# Altium schematic sheet MOLEX_connectors.SchDoc
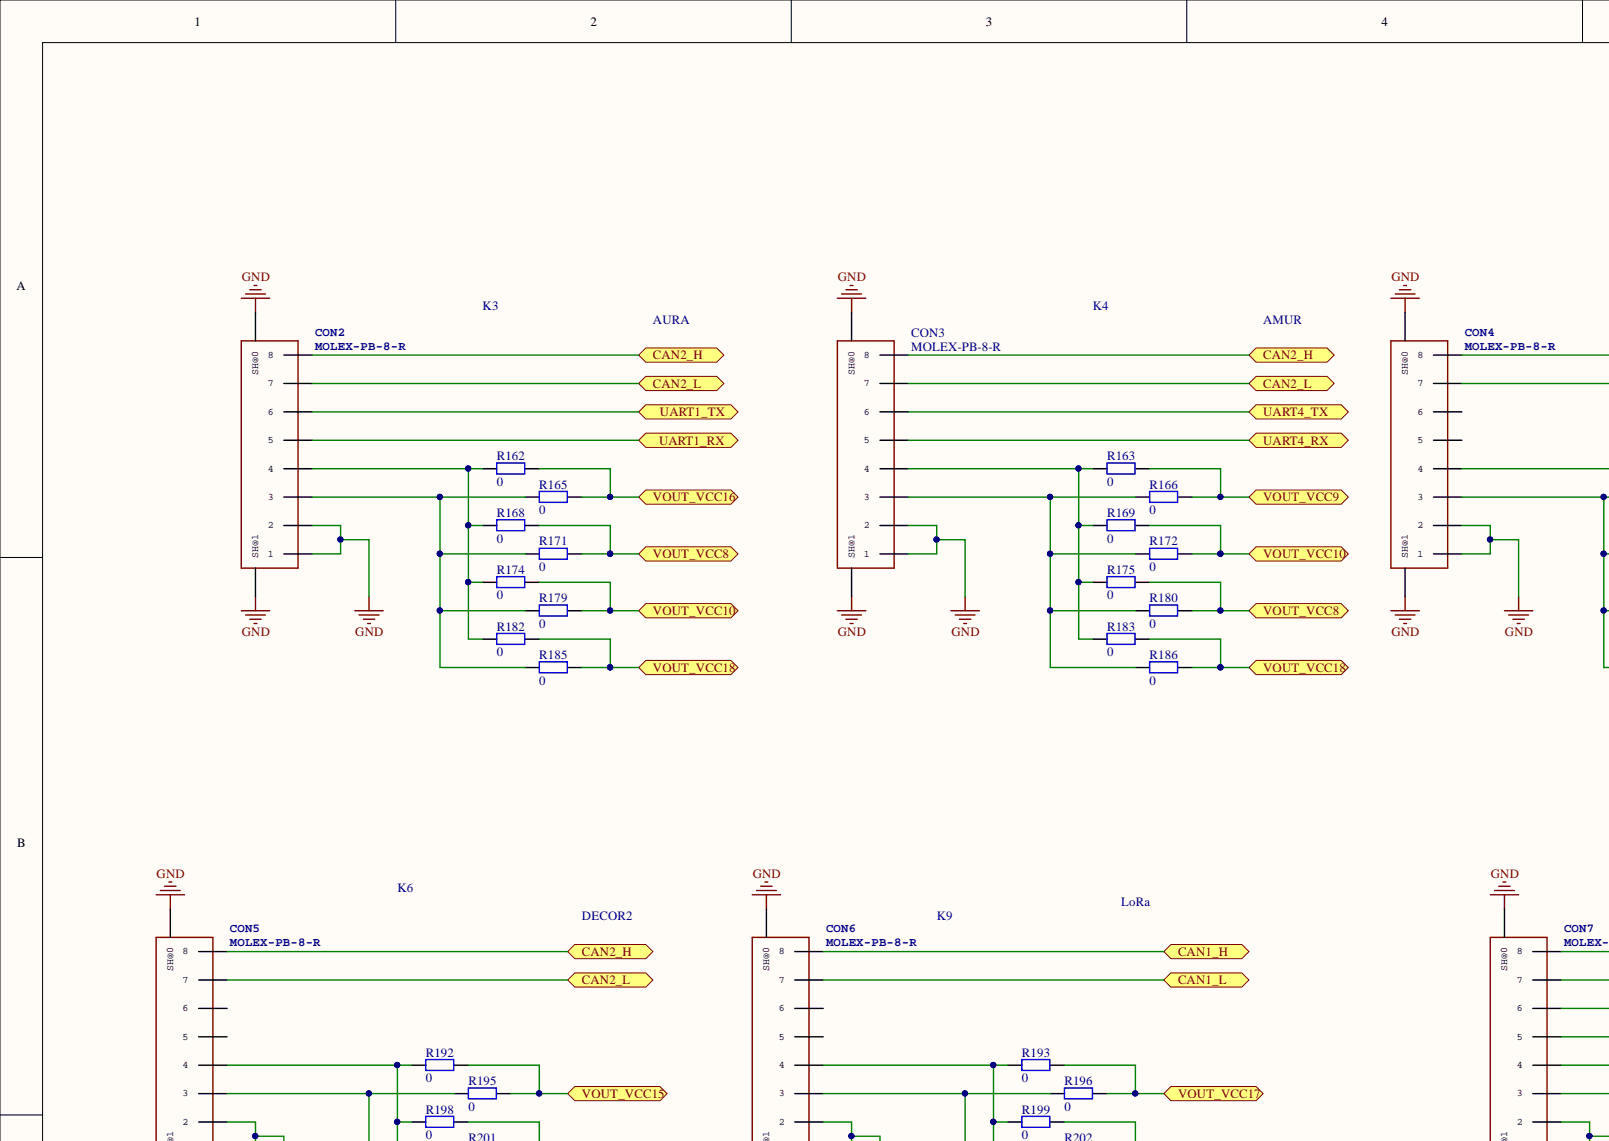
[diagram p0: MOLEX_connectors.SchDoc, top left]
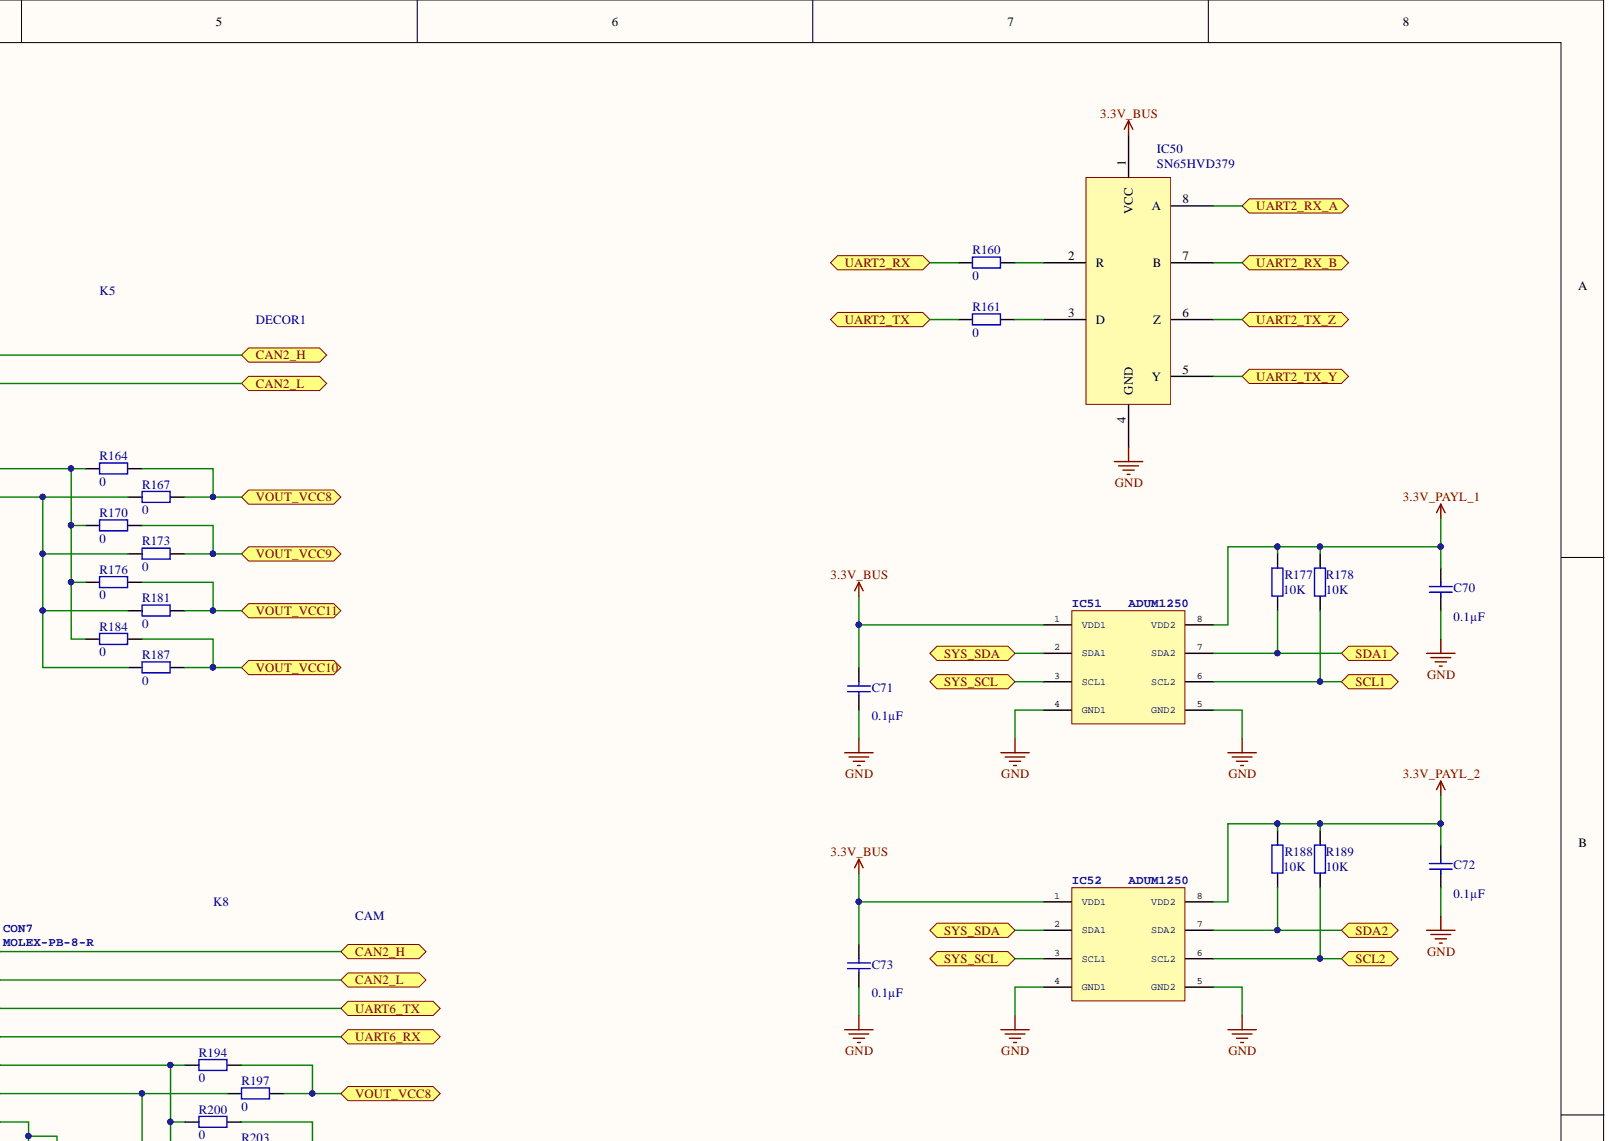
[diagram p1: MOLEX_connectors.SchDoc, top right]
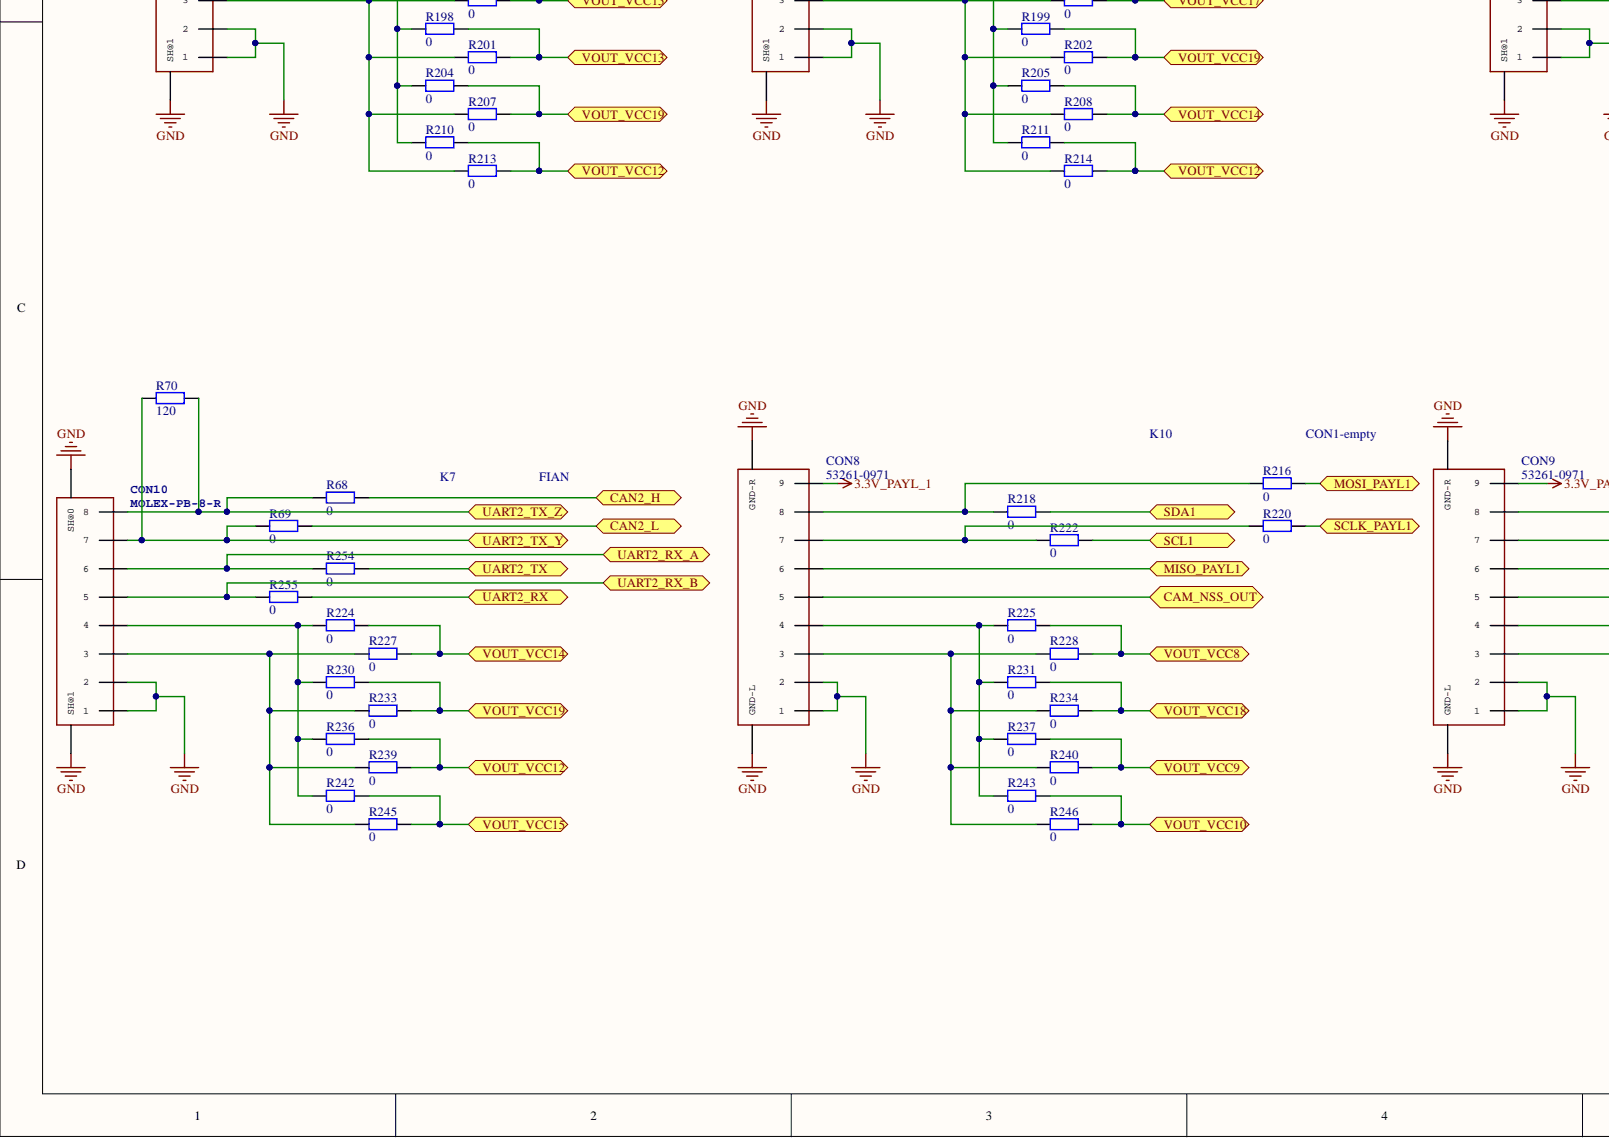
[diagram p2: MOLEX_connectors.SchDoc, bottom left]
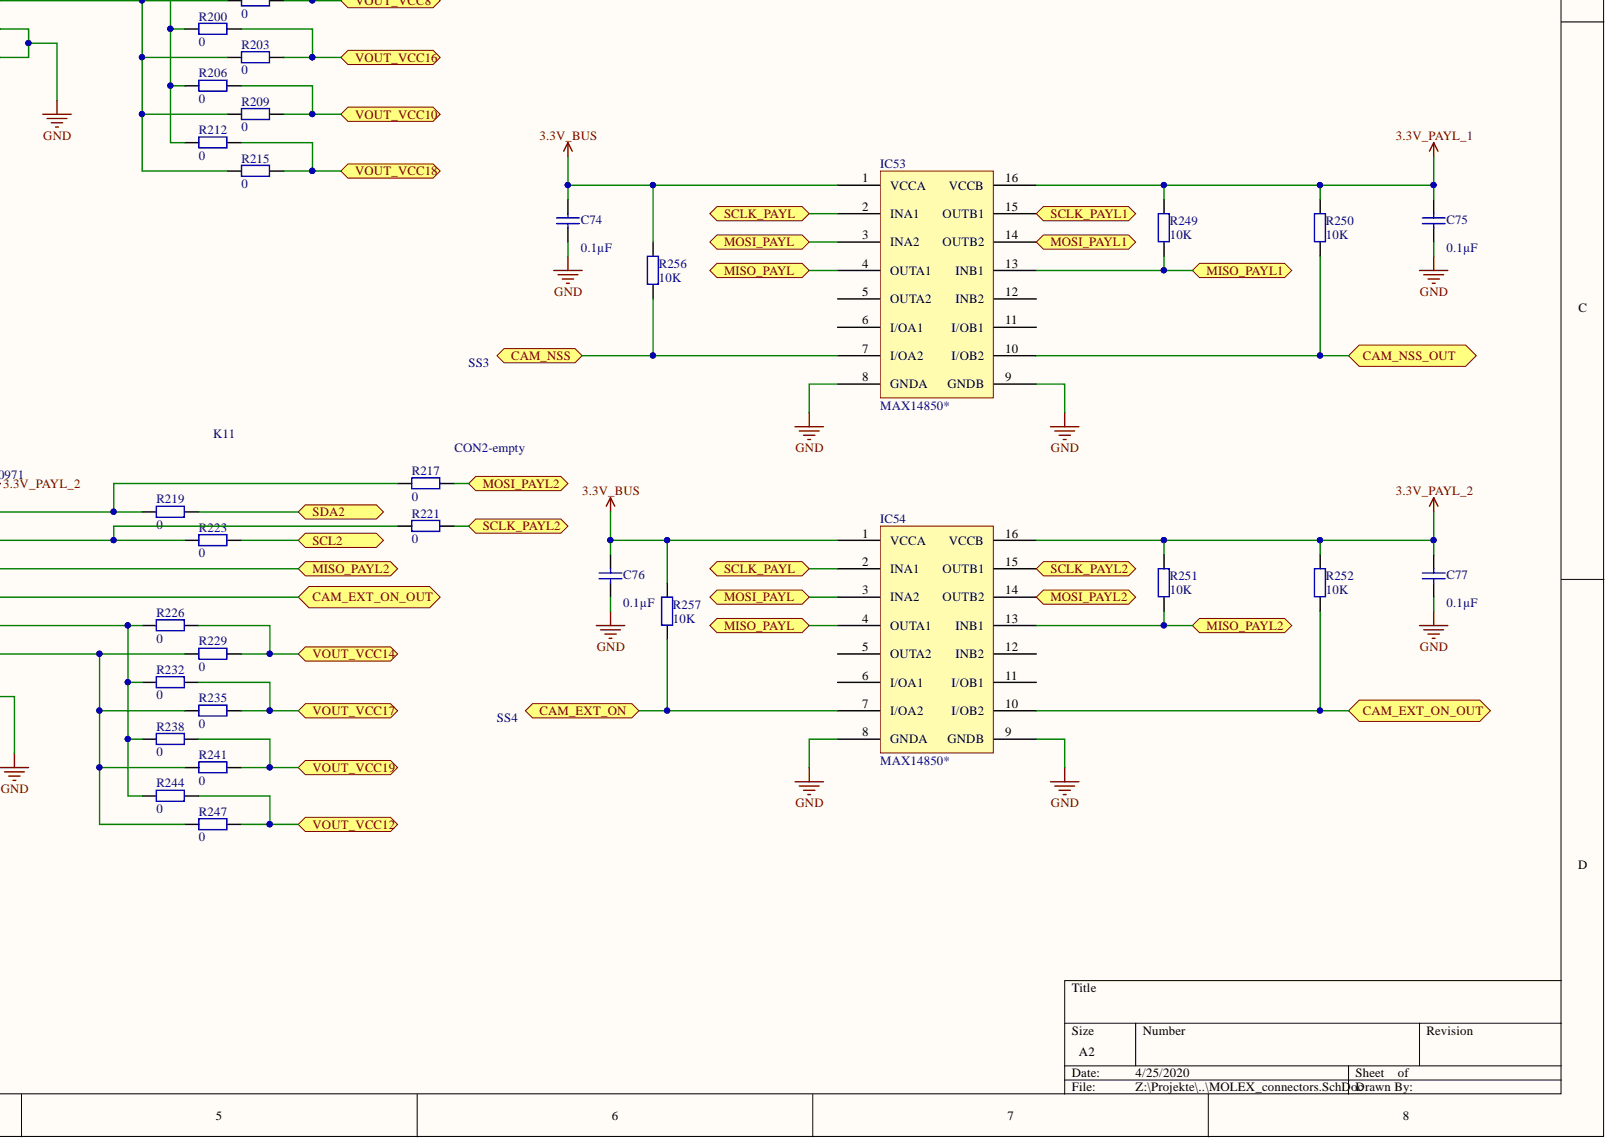
[diagram p3: MOLEX_connectors.SchDoc, bottom right]

## Parts
C70  0.1µF  [1608[0603]]
C71  0.1µF  [1608[0603]]
C72  0.1µF  [1608[0603]]
C73  0.1µF  [1608[0603]]
C74  0.1µF  [1608[0603]]
C75  0.1µF  [1608[0603]]
C76  0.1µF  [1608[0603]]
C77  0.1µF  [1608[0603]]
CON2  MOLEX-PB-8-R  [53261-0871]
CON3  MOLEX-PB-8-R  [53261-0871]
CON4  MOLEX-PB-8-R  [53261-0871]
CON5  MOLEX-PB-8-R  [53261-0871]
CON6  MOLEX-PB-8-R  [53261-0871]
CON7  MOLEX-PB-8-R  [53261-0871]
CON8  53261-0971  [53261-0971]
CON9  53261-0971  [53261-0971]
CON10  MOLEX-PB-8-R  [53261-0871]
IC50  SN65HVD379  [SOIC127P600X175-8N]
IC51  ADUM1250  [SO08]
IC52  ADUM1250  [SO08]
IC53  MAX14850*  [SOIC127P600X175-16N]
IC54  MAX14850*  [SOIC127P600X175-16N]
R68  0  [1608[0603]]
R69  0  [1608[0603]]
R70  120  [1608[0603]]
R160  value 0  [1608[0603]]  (hidden comment: 0 n.p.)
R161  value 0  [1608[0603]]  (hidden comment: 0 n.p.)
R162  value 0  [6-0805_N]  (hidden comment: 0 n.p.)
R163  0  [6-0805_N]
R164  value 0  [6-0805_N]  (hidden comment: 0 n.p.)
R165  value 0  [6-0805_N]  (hidden comment: 0 n.p.)
R166  0  [6-0805_N]
R167  value 0  [6-0805_N]  (hidden comment: 0 n.p.)
R168  0  [6-0805_N]
R169  value 0  [6-0805_N]  (hidden comment: 0 n.p.)
R170  value 0  [6-0805_N]  (hidden comment: 0 n.p.)
R171  0  [6-0805_N]
R172  value 0  [6-0805_N]  (hidden comment: 0 n.p.)
R173  value 0  [6-0805_N]  (hidden comment: 0 n.p.)
R174  value 0  [6-0805_N]  (hidden comment: 0 n.p.)
R175  value 0  [6-0805_N]  (hidden comment: 0 n.p.)
R176  0  [6-0805_N]
R177  10K  [1608[0603]]
R178  10K  [1608[0603]]
R179  value 0  [6-0805_N]  (hidden comment: 0 n.p.)
R180  value 0  [6-0805_N]  (hidden comment: 0 n.p.)
R181  value 0  [6-0805_N]  (hidden comment: 0 n.p.)
R182  value 0  [6-0805_N]  (hidden comment: 0 n.p.)
R183  value 0  [6-0805_N]  (hidden comment: 0 n.p.)
R184  value 0  [6-0805_N]  (hidden comment: 0 n.p.)
R185  value 0  [6-0805_N]  (hidden comment: 0 n.p.)
R186  value 0  [6-0805_N]  (hidden comment: 0 n.p.)
R187  0  [6-0805_N]
R188  10K  [1608[0603]]
R189  10K  [1608[0603]]
R192  value 0  [6-0805_N]  (hidden comment: 0 n.p.)
R193  0  [6-0805_N]
R194  value 0  [6-0805_N]  (hidden comment: 0 n.p.)
R195  value 0  [6-0805_N]  (hidden comment: 0 n.p.)
R196  0  [6-0805_N]
R197  value 0  [6-0805_N]  (hidden comment: 0 n.p.)
R198  0  [6-0805_N]
R199  value 0  [6-0805_N]  (hidden comment: 0 n.p.)
R200  0  [6-0805_N]
R201  value 0  [6-0805_N]  (hidden comment: 0 n.p.)
R202  value 0  [6-0805_N]  (hidden comment: 0 n.p.)
R203  0  [6-0805_N]
R204  value 0  [6-0805_N]  (hidden comment: 0 n.p.)
R205  value 0  [6-0805_N]  (hidden comment: 0 n.p.)
R206  value 0  [6-0805_N]  (hidden comment: 0 n.p.)
R207  value 0  [6-0805_N]  (hidden comment: 0 n.p.)
R208  value 0  [6-0805_N]  (hidden comment: 0 n.p.)
R209  value 0  [6-0805_N]  (hidden comment: 0 n.p.)
R210  value 0  [6-0805_N]  (hidden comment: 0 n.p.)
R211  value 0  [6-0805_N]  (hidden comment: 0 n.p.)
R212  value 0  [6-0805_N]  (hidden comment: 0 n.p.)
R213  0  [6-0805_N]
R214  value 0  [6-0805_N]  (hidden comment: 0 n.p.)
R215  value 0  [6-0805_N]  (hidden comment: 0 n.p.)
R216  0  [1608[0603]]
R217  0  [1608[0603]]
R218  0  [1608[0603]]
R219  0  [1608[0603]]
R220  0  [1608[0603]]
R221  0  [1608[0603]]
R222  0  [1608[0603]]
R223  0  [1608[0603]]
R224  0  [6-0805_N]
R225  value 0  [6-0805_N]  (hidden comment: 0 n.p.)
R226  value 0  [6-0805_N]  (hidden comment: 0 n.p.)
R227  value 0  [6-0805_N]  (hidden comment: 0 n.p.)
R228  value 0  [6-0805_N]  (hidden comment: 0 n.p.)
R229  value 0  [6-0805_N]  (hidden comment: 0 n.p.)
R230  value 0  [6-0805_N]  (hidden comment: 0 n.p.)
R231  0  [6-0805_N]
R232  value 0  [6-0805_N]  (hidden comment: 0 n.p.)
R233  value 0  [6-0805_N]  (hidden comment: 0 n.p.)
R234  0  [6-0805_N]
R235  value 0  [6-0805_N]  (hidden comment: 0 n.p.)
R236  value 0  [6-0805_N]  (hidden comment: 0 n.p.)
R237  value 0  [6-0805_N]  (hidden comment: 0 n.p.)
R238  0  [6-0805_N]
R239  value 0  [6-0805_N]  (hidden comment: 0 n.p.)
R240  value 0  [6-0805_N]  (hidden comment: 0 n.p.)
R241  0  [6-0805_N]
R242  value 0  [6-0805_N]  (hidden comment: 0 n.p.)
R243  value 0  [6-0805_N]  (hidden comment: 0 n.p.)
R244  value 0  [6-0805_N]  (hidden comment: 0 n.p.)
R245  0  [6-0805_N]
R246  value 0  [6-0805_N]  (hidden comment: 0 n.p.)
R247  value 0  [6-0805_N]  (hidden comment: 0 n.p.)
R249  10K  [1608[0603]]
R250  10K  [1608[0603]]
R251  10K  [1608[0603]]
R252  10K  [1608[0603]]
R254  value 0  [1608[0603]]  (hidden comment: 0 n.p.)
R255  value 0  [1608[0603]]  (hidden comment: 0 n.p.)
R256  10K  [1608[0603]]
R257  10K  [1608[0603]]

## Ports
CAM_EXT_ON, CAM_EXT_ON_OUT, CAM_NSS, CAM_NSS_OUT, CAN1_H, CAN1_L, CAN2_H, CAN2_L, MISO_PAYL, MISO_PAYL1, MISO_PAYL2, MOSI_PAYL, MOSI_PAYL1, MOSI_PAYL2, SCL1, SCL2, SCLK_PAYL, SCLK_PAYL1, SCLK_PAYL2, SDA1, SDA2, SYS_SCL, SYS_SDA, UART1_RX, UART1_TX, UART2_RX, UART2_RX_A, UART2_RX_B, UART2_TX, UART2_TX_Y, UART2_TX_Z, UART4_RX, UART4_TX, UART6_RX, UART6_TX, VOUT_VCC8, VOUT_VCC9, VOUT_VCC10, VOUT_VCC11, VOUT_VCC12, VOUT_VCC13, VOUT_VCC14, VOUT_VCC15, VOUT_VCC16, VOUT_VCC17, VOUT_VCC18, VOUT_VCC19

## Nets
3.3V_BUS: C71.2 C73.2 C74.2 C76.2 IC50.1(VCC) IC51.1(VDD1) IC52.1(VDD1) IC53.1(VCCA) IC54.1(VCCA) R256.1 R257.1
3.3V_PAYL_1: C70.2 C75.2 CON8.9 IC51.8(VDD2) IC53.16(VCCB) R177.2 R178.2 R249.2 R250.2
3.3V_PAYL_2: C72.2 C77.2 CON9.9 IC52.8(VDD2) IC54.16(VCCB) R188.2 R189.2 R251.2 R252.2
GND: C70.1 C71.1 C72.1 C73.1 C74.1 C75.1 C76.1 C77.1 CON2.P$1 CON2.P$2 CON2.P$11(SH@0) CON2.P$12(SH@1) CON3.P$1 CON3.P$2 CON3.P$11(SH@0) CON3.P$12(SH@1) CON4.P$1 CON4.P$2 CON4.P$11(SH@0) CON4.P$12(SH@1) CON5.P$1 CON5.P$2 CON5.P$11(SH@0) CON5.P$12(SH@1) CON6.P$1 CON6.P$2 CON6.P$11(SH@0) CON6.P$12(SH@1) CON7.P$1 CON7.P$2 CON7.P$11(SH@0) CON7.P$12(SH@1) CON8.1 CON8.2 CON8.GND-L CON8.GND-R CON9.1 CON9.2 CON9.GND-L CON9.GND-R CON10.P$1 CON10.P$2 CON10.P$11(SH@0) CON10.P$12(SH@1) IC50.4(GND) IC51.4(GND1) IC51.5(GND2) IC52.4(GND1) IC52.5(GND2) IC53.8(GNDA) IC53.9(GNDB) IC54.8(GNDA) IC54.9(GNDB)
CAM_EXT_ON: IC54.7(I/OA2) R257.2 ; port CAM_EXT_ON
CAM_EXT_ON_OUT: CON9.5 IC54.10(I/OB2) R252.1 ; port CAM_EXT_ON_OUT
CAM_NSS: IC53.7(I/OA2) R256.2 ; port CAM_NSS
CAM_NSS_OUT: CON8.5 IC53.10(I/OB2) R250.1 ; port CAM_NSS_OUT
CAN1_H: CON6.P$8 ; port CAN1_H
CAN1_L: CON6.P$7 ; port CAN1_L
CAN2_H: CON2.P$8 CON3.P$8 CON4.P$8 CON5.P$8 CON7.P$8 R68.2 ; port CAN2_H
CAN2_L: CON2.P$7 CON3.P$7 CON4.P$7 CON5.P$7 CON7.P$7 R69.2 ; port CAN2_L
MISO_PAYL: IC53.4(OUTA1) IC54.4(OUTA1) ; port MISO_PAYL
MISO_PAYL1: CON8.6 IC53.13(INB1) R249.1 ; port MISO_PAYL1
MISO_PAYL2: CON9.6 IC54.13(INB1) R251.1 ; port MISO_PAYL2
MOSI_PAYL: IC53.3(INA2) IC54.3(INA2) ; port MOSI_PAYL
MOSI_PAYL1: IC53.14(OUTB2) R216.2 ; port MOSI_PAYL1
MOSI_PAYL2: IC54.14(OUTB2) R217.2 ; port MOSI_PAYL2
SCL1: IC51.6(SCL2) R178.1 R222.2 ; port SCL1
SCL2: IC52.6(SCL2) R189.1 R223.2 ; port SCL2
SCLK_PAYL: IC53.2(INA1) IC54.2(INA1) ; port SCLK_PAYL
SCLK_PAYL1: IC53.15(OUTB1) R220.2 ; port SCLK_PAYL1
SCLK_PAYL2: IC54.15(OUTB1) R221.2 ; port SCLK_PAYL2
SDA1: IC51.7(SDA2) R177.1 R218.2 ; port SDA1
SDA2: IC52.7(SDA2) R188.1 R219.2 ; port SDA2
SYS_SCL: IC51.3(SCL1) IC52.3(SCL1) ; port SYS_SCL
SYS_SDA: IC51.2(SDA1) IC52.2(SDA1) ; port SYS_SDA
UART1_RX: CON2.P$5 ; port UART1_RX
UART1_TX: CON2.P$6 ; port UART1_TX
UART2_RX: R160.1 R255.2 ; port UART2_RX
UART2_RX_A: CON10.P$6 IC50.8(A) R254.1 ; port UART2_RX_A
UART2_RX_B: CON10.P$5 IC50.7(B) R255.1 ; port UART2_RX_B
UART2_TX: R161.1 R254.2 ; port UART2_TX
UART2_TX_Y: CON10.P$7 IC50.5(Y) R69.1 R70.1 ; port UART2_TX_Y
UART2_TX_Z: CON10.P$8 IC50.6(Z) R68.1 R70.2 ; port UART2_TX_Z
UART4_RX: CON3.P$5 ; port UART4_RX
UART4_TX: CON3.P$6 ; port UART4_TX
UART6_RX: CON7.P$5 ; port UART6_RX
UART6_TX: CON7.P$6 ; port UART6_TX
VOUT_VCC8: R164.2 R167.2 R168.2 R171.2 R175.2 R180.2 R194.2 R197.2 R225.2 R228.2 ; port VOUT_VCC8
VOUT_VCC9: R163.2 R166.2 R170.2 R173.2 R237.2 R240.2 ; port VOUT_VCC9
VOUT_VCC10: R169.2 R172.2 R174.2 R179.2 R184.2 R187.2 R206.2 R209.2 R243.2 R246.2 ; port VOUT_VCC10
VOUT_VCC11: R176.2 R181.2 ; port VOUT_VCC11
VOUT_VCC12: R210.2 R211.2 R213.2 R214.2 R236.2 R239.2 R244.2 R247.2 ; port VOUT_VCC12
VOUT_VCC13: R198.2 R201.2 ; port VOUT_VCC13
VOUT_VCC14: R205.2 R208.2 R224.2 R226.2 R227.2 R229.2 ; port VOUT_VCC14
VOUT_VCC15: R192.2 R195.2 R242.2 R245.2 ; port VOUT_VCC15
VOUT_VCC16: R162.2 R165.2 R200.2 R203.2 ; port VOUT_VCC16
VOUT_VCC17: R193.2 R196.2 R232.2 R235.2 ; port VOUT_VCC17
VOUT_VCC18: R182.2 R183.2 R185.2 R186.2 R212.2 R215.2 R231.2 R234.2 ; port VOUT_VCC18
VOUT_VCC19: R199.2 R202.2 R204.2 R207.2 R230.2 R233.2 R238.2 R241.2 ; port VOUT_VCC19
NetCON2_P$3: CON2.P$3 R165.1 R171.1 R179.1 R185.1
NetCON2_P$4: CON2.P$4 R162.1 R168.1 R174.1 R182.1
NetCON3_P$3: CON3.P$3 R166.1 R172.1 R180.1 R186.1
NetCON3_P$4: CON3.P$4 R163.1 R169.1 R175.1 R183.1
NetCON4_P$3: CON4.P$3 R167.1 R173.1 R181.1 R187.1
NetCON4_P$4: CON4.P$4 R164.1 R170.1 R176.1 R184.1
NetCON5_P$3: CON5.P$3 R195.1 R201.1 R207.1 R213.1
NetCON5_P$4: CON5.P$4 R192.1 R198.1 R204.1 R210.1
NetCON6_P$3: CON6.P$3 R196.1 R202.1 R208.1 R214.1
NetCON6_P$4: CON6.P$4 R193.1 R199.1 R205.1 R211.1
NetCON7_P$3: CON7.P$3 R197.1 R203.1 R209.1 R215.1
NetCON7_P$4: CON7.P$4 R194.1 R200.1 R206.1 R212.1
NetCON8_3: CON8.3 R228.1 R234.1 R240.1 R246.1
NetCON8_4: CON8.4 R225.1 R231.1 R237.1 R243.1
NetCON8_7: CON8.7 R220.1 R222.1
NetCON8_8: CON8.8 R216.1 R218.1
NetCON9_3: CON9.3 R229.1 R235.1 R241.1 R247.1
NetCON9_4: CON9.4 R226.1 R232.1 R238.1 R244.1
NetCON9_7: CON9.7 R221.1 R223.1
NetCON9_8: CON9.8 R217.1 R219.1
NetCON10_P$3: CON10.P$3 R227.1 R233.1 R239.1 R245.1
NetCON10_P$4: CON10.P$4 R224.1 R230.1 R236.1 R242.1
NetIC50_2: IC50.2(R) R160.2
NetIC50_3: IC50.3(D) R161.2

Not connected: CON4 P$5 P$6; CON5 P$5 P$6; CON6 P$5 P$6; IC53 5(OUTA2) 6(I/OA1) 11(I/OB1) 12(INB2); IC54 5(OUTA2) 6(I/OA1) 11(I/OB1) 12(INB2)

## Library data of the parts
C70-C77 = Cap: Capacitor; Component Kind: Standard; LatestRevisionDate: 17-Jul-2002; LatestRevisionNote: Re-released for DXP Platform.; Library Name: Miscellaneous Devices.IntLib; PackageDescription: Radial Cap, Thru-Hole; 2 Leads; 0.3 in Pin Spacing; PackageReference: RAD-0.3; Published: 8-Jun-2000; Signal Integrity: Cap; Simulation: CAP; Value: 0.1µF; Publisher: Altium Limited
CON8 CON9 = MOLEX-RB-9-R: Headers & Wire Housings 1.25MM HDR 9 CKT Right-Angle; Component Kind: Standard; Library Name: PicoBlade_pavel.SCHLIB
IC53 IC54 = MAX14850_2: Six-Channel Digital Isolator; Component Kind: Standard; Library Name: EPS_Pavel.SCHLIB
R68-R70 R160-R189 R192-R247 R249-R252 R254-R257 = Res2: Resistor; Component Kind: Standard; LatestRevisionDate: 17-Jul-2002; LatestRevisionNote: Re-released for DXP Platform.; Library Name: Miscellaneous Devices.IntLib; PackageDescription: Axial Device, Thru-Hole; 2 Leads; 0.4 in Pin Spacing; PackageReference: AXIAL-0.4; Published: 8-Jun-2000; Signal Integrity: Res; Simulation: RESISTOR; Publisher: Altium Limited
  R68 R69 R163 R166 R168 R171 R176 R187 R193 R196 R198 R200 R203 R213 R216-R224 R231 R234 R238 R241 R245: Value: 0
  R70: Value: 120
  R177 R178 R188 R189 R249-R252 R256 R257: Value: 10K
CON2-CON7 CON10 = MOLEX-PB-8-R: Component Kind: Standard; Library Name: PicoBlade_pavel.SCHLIB
IC50 = SN65HVD379: Component Kind: Standard; Library Name: EPS_Pavel.SCHLIB
IC51 IC52 = ADUM1250: Component Kind: Standard; Library Name: ICs_Pavel.SCHLIB
